# Altium schematic sheet Smart Agriculture Schematic (easyeda backup).SchDoc
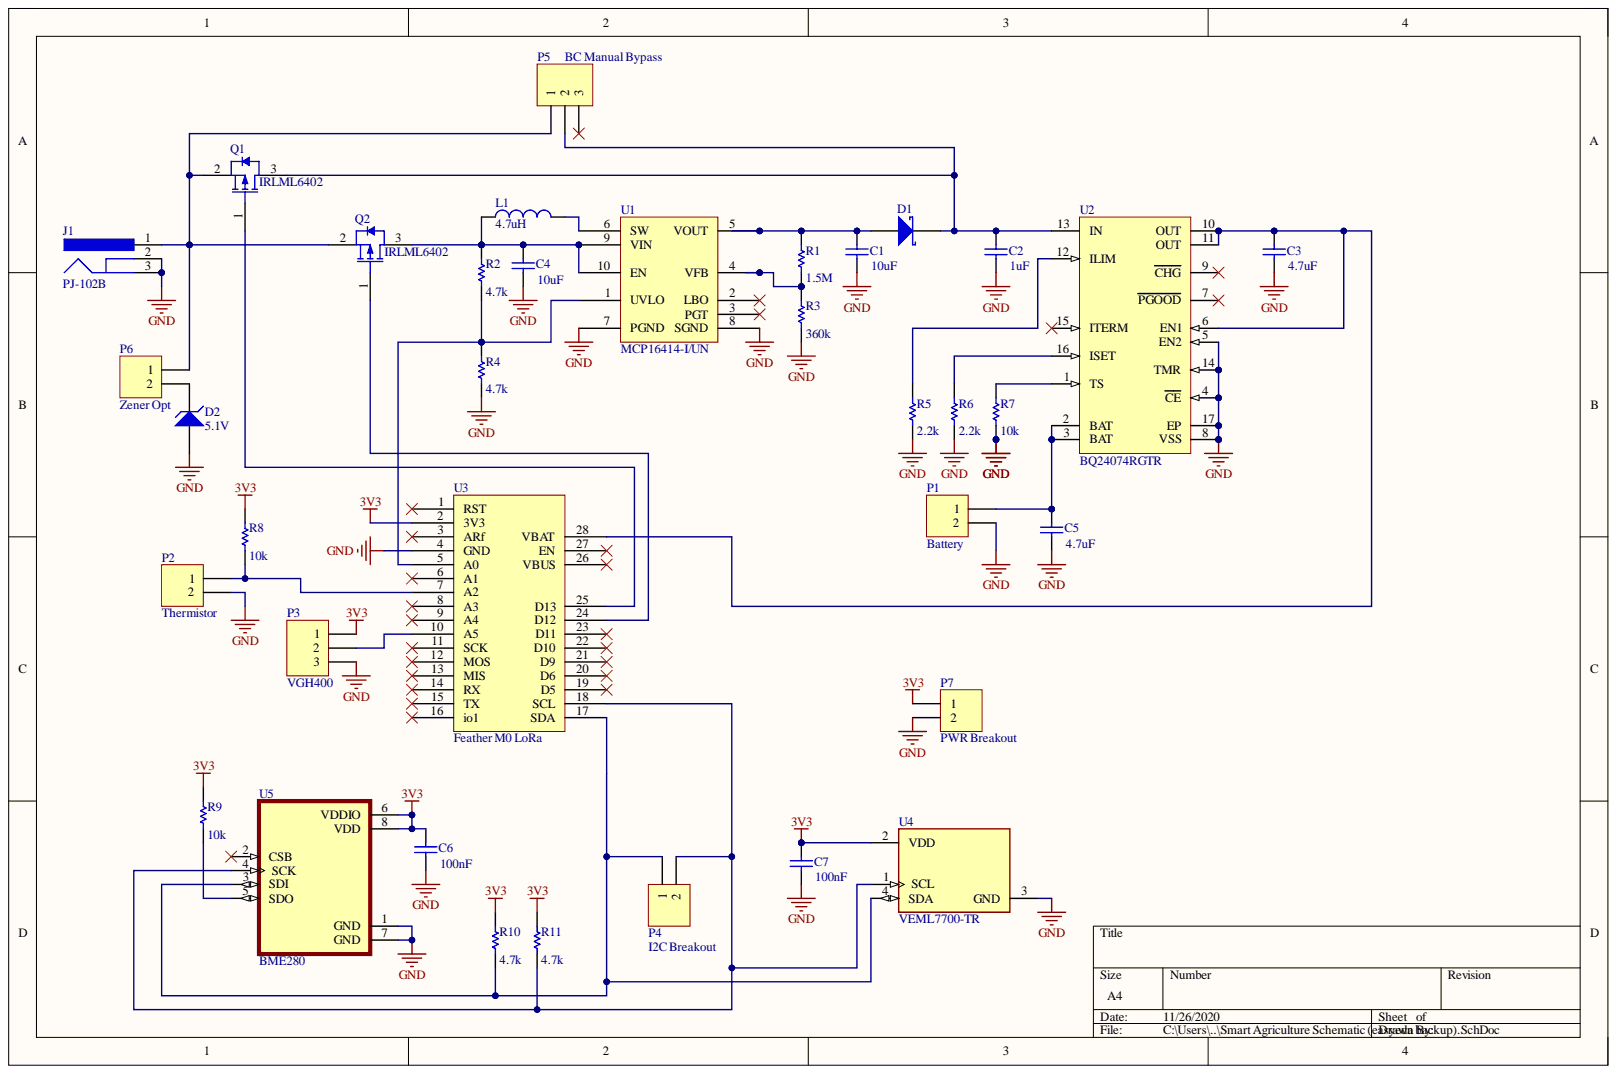
[diagram p0: Smart Agriculture Schematic (easyeda backup).SchDoc]

## Parts
C1  value 10uF  [1608[0603]]
C2  value 1uF  [1608[0603]]
C3  value 4.7uF  [1608[0603]]
C4  value 10uF  [1608[0603]]
C5  value 4.7uF  [1608[0603]]
C6  value 100nF  [1608[0603]]
C7  value 100nF  [1608[0603]]
D1  D Schottky  [SMB]  (value not drawn)
D2  5.1V  [SMC]
J1  PJ-102B  [CUI-PJ-102B_V]
L1  value 4.7uH  [1812]
P1  Battery  [HDR1X2]
P2  Thermistor  [HDR1X2]
P3  VGH400  [HDR1X3]
P4  I2C Breakout  [HDR1X2]
P5  BC Manual Bypass  [HDR1X3]
P6  Zener Opt  [HDR1X2]
P7  PWR Breakout  [HDR1X2]
Q1  IRLML6402  [INTR-SOT-23-3_V]
Q2  IRLML6402  [INTR-SOT-23-3_V]
R1  value 1.5M  [1608[0603]]
R2  value 4.7k  [1608[0603]]
R3  value 360k  [1608[0603]]
R4  value 4.7k  [1608[0603]]
R5  value 2.2k  [1608[0603]]
R6  value 2.2k  [1608[0603]]
R7  value 10k  [1608[0603]]
R8  value 10k  [1608[0603]]
R9  value 10k  [1608[0603]]
R10  value 4.7k  [1608[0603]]
R11  value 4.7k  [1608[0603]]
U1  MCP16414-I/UN  [MSOP10_UN_MCH]
U2  BQ24074RGTR  [RGT0016C_V]
U3  Feather M0 LoRa  [FeatherM0LoRa]
U4  VEML7700-TR  [FP-6_550-5300_02-4-MFG]
U5  BME280  [PSON65P250X250X100-8N]

## Nets
3V3: C6.2 C7.2 P3.1 P7.1 R8.2 R9.2 R10.2 R11.2 U3.2(3V3) U4.2(VDD) U5.6(VDDIO) U5.8(VDD)
GND: C1.1 C2.1 C3.1 C4.1 C5.1 C6.1 C7.1 D2.1 J1.2 J1.3 P1.2 P2.2 P3.3 P7.2 R3.1 R4.1 R5.1 R6.1 R7.1 U1.7(PGND) U1.8(SGND) U2.4(CE) U2.5(EN2) U2.8(VSS) U2.14(TMR) U2.17(EP) U3.4(GND) U4.3(GND) U5.1(GND) U5.7(GND)
NetC1_2: C1.2 D1.1 R1.2 U1.5(VOUT)
NetC2_2: C2.2 D1.2 P5.2 Q1.3 U2.13(IN)
NetC3_2: C3.2 U2.6(EN1) U2.10(OUT) U2.11(OUT) U3.28(VBAT)
NetC4_2: C4.2 L1.1 Q2.3 R2.2 U1.9(VIN) U1.10(EN)
NetC5_2: C5.2 P1.1 U2.2(BAT) U2.3(BAT)
NetD2_2: D2.2 P6.2
NetJ1_1: J1.1 P5.1 P6.1 Q1.2 Q2.2
NetL1_2: L1.2 U1.6(SW)
NetP2_1: P2.1 R8.1 U3.7(A2)
NetP3_2: P3.2 U3.10(A5)
NetP4_1: P4.1 R10.1 U3.17(SDA) U4.4(SDA) U5.3(SDI)
NetP4_2: P4.2 R11.1 U3.18(SCL) U4.1(SCL) U5.4(SCK)
NetQ1_1: Q1.1 U3.25(D13)
NetQ2_1: Q2.1 U3.24(D12)
NetR1_1: R1.1 R3.2 U1.4(VFB)
NetR2_1: R2.1 R4.2 U1.1(UVLO) U3.5(A0)
NetR5_2: R5.2 U2.12(ILIM)
NetR6_2: R6.2 U2.16(ISET)
NetR7_2: R7.2 U2.1(TS)
NetR9_1: R9.1 U5.5(SDO)

Not connected: P5 3; U1 2(LBO) 3(PGT); U2 7(PGOOD) 9(CHG) 15(ITERM); U3 1(RST) 3(ARf) 6(A1) 8(A3) 9(A4) 11(SCK) 12(MOS) 13(MIS) 14(RX) 15(TX) 16(io1) 19(D5) 20(D6) 21(D9) 22(D10) 23(D11) 26(VBUS) 27(EN); U5 2(CSB)

## Library data of the parts
C1-C7 = Cap: Capacitor; Component Kind: Standard; LatestRevisionDate: 17-Jul-2002; LatestRevisionNote: Re-released for DXP Platform.; Library Name: Miscellaneous Devices.IntLib; PackageDescription: Radial Cap, Thru-Hole; 2 Leads; 0.3 in Pin Spacing; PackageReference: RAD-0.3; Published: 8-Jun-2000; Signal Integrity: Cap; Simulation: CAP; Publisher: Altium Limited
D1 = D Schottky: Schottky Diode; Code_JEDEC: DO-214-AA; Component Kind: Standard; LatestRevisionDate: 17-Jul-2002; LatestRevisionNote: Re-released for DXP Platform.; Library Name: Miscellaneous Devices.IntLib; PackageDescription: DO-214-AA/SMB; 2 C-Bend Leads; Body 5.3 x 3.6 mm, inc. leads (LxW); PackageReference: SMB; Published: 8-Jun-2000; Signal Integrity: D Schottky; Simulation: SKYDIODE; Publisher: Altium Limited
D2 = D Zener: Zener Diode; Component Kind: Standard; LatestRevisionDate: 17-Jul-2002; LatestRevisionNote: Re-released for DXP Platform.; Library Name: Miscellaneous Devices.IntLib; PackageDescription: Diode, Thru-Hole; 2 Leads; 0.7 in Pin Spacing; PackageReference: DIODE-0.7; Published: 8-Jun-2000; Signal Integrity: D Zener; Simulation: ZENER; Publisher: Altium Limited
J1 = CMP-2000-06900-1: Through Hole Right Angle DC Power Jack, 2.5 A, 2.5 mm Center Pin, 3 Position, -25 to 85 degC, RoHS, Bulk; Manufacturer: CUIInc.; Brand: CUI; Current Rating: 2.5A; Inside Contact Diameter: 2.5mm; Insulation Resistance: 100MOhms; Outside Contact Diameter: 6.5mm; Voltage Rating: 24V; Component Kind: Standard; Connector Type: DCPowerJack; Contact Gender: Male; Contact Material: Copper; Contact Plating: Nickel; Datasheet URL: http://www.cui.com/product/resource/pj-102b.pdf; Datasheet Version: Rev. 1.03, 04/2016; Factory Pack Quantity: 280; Flammability Rating: UL94V-0; Housing Material: PolybutyleneTerephthalate(PBT); Library Name: Altium Content Vault; Manufacturer URL: http://www.cui.com/; Mounting Angle: Right; Mounting Style: ThroughHole; Mounting Technology: ThroughHole; Operating Temperature Range: -25Cto+85C; Package Description: 3-Pin Through Hole Device, Body 14.4 x 9 mm, Height 11 mm; Package Reference: PJ-102B; Packaging: Bulk; Product: Jacks; Product Category: DCPowerConnectors; RoHS: True; Termination Style: ThroughHole
L1 = Inductor: Code_IEC: 1005; Code_JEITA: 0402; Component Kind: Standard; Description: Inductor; LatestRevisionDate: 29-May-2009; LatestRevisionNote: IPC-7351 Footprint Added.; Library Name: Miscellaneous Devices.IntLib; PackageDescription: Chip Inductor; PackageReference: 0402-A; Published: 8-Jun-2000; Signal Integrity: Inductor; Simulation: INDUCTOR; Publisher: Altium Limited
P1 P2 P4 P6 P7 = Header 2: Header, 2-Pin; Component Kind: Standard; LatestRevisionDate: 17-Jul-2002; LatestRevisionNote: Re-released for DXP Platform.; Library Name: Miscellaneous Connectors.IntLib; Signal Integrity: Connector; Publisher: Altium Limited
P3 P5 = Header 3: Header, 3-Pin; Component Kind: Standard; LatestRevisionDate: 17-Jul-2002; LatestRevisionNote: Re-released for DXP Platform.; Library Name: Miscellaneous Connectors.IntLib; Signal Integrity: Connector; Publisher: Altium Limited
Q1 Q2 = CMP-2000-04987-1: HEXFET(R) Power P-Channel MOSFET, -20 V, -3.7 A, -55 to 150 degC, 3-Pin SOT-23, RoHS, Tape and Reel; Manufacturer: International Rectifier; Drain-Source On Resistance-Max: 0.065O; Drain-to-Source Voltage [Vdss]: 20V; Qg Gate Charge: 8nC; Rated Power Dissipation: 1.3W; Channel Type: P-Channel; Component Kind: Standard; Datasheet URL: http://datasheet.octopart.com/IRLML6402TRPBF-International-Rectifier-datasheet-93882.pdf; Datasheet Version: Feb-05; Library Name: Altium Content Vault; Manufacturer URL: http://internationalrectifier.com/; Package Description: 3-Pin Small Outline Transistor, Body 2.92 x 1.3 mm, Pitch 0.95 mm; Package Reference: SOT-23-3
R1-R11 = Res1: Resistor; Component Kind: Standard; LatestRevisionDate: 17-Jul-2002; LatestRevisionNote: Re-released for DXP Platform.; Library Name: Miscellaneous Devices.IntLib; PackageDescription: Axial Device, Thru-Hole; 2 Leads; 0.3 in Pin Spacing; PackageReference: AXIAL-0.3; Published: 8-Jun-2000; Signal Integrity: Res; Simulation: RESISTOR; Publisher: Altium Limited
U1 = Component_1: Component Kind: Standard; Library Name: MCP16414-IUN.SchLib
U2 = CMP-0321-00220-2: USB-Friendly Li-Ion Battery Charger and Power-Path Management IC, 10.5 V OVP, 4.3 V, -40 to 85 degC, 16-pin QFN (RGT), Green (RoHS & no Sb/Br); Manufacturer: Texas Instruments; Lead Acid: N; SubFamily: Battery Charge Management; Vin(Min)(V): 4.3; Code_JEDEC: MO-220; Component Kind: Standard; ComponentLink1Description: Datasheet; ComponentLink1URL: http://www.ti.com/general/docs/lit/getliterature.tsp?genericPartNumber=BQ24074&fileType=pdf; DatasheetVersion: SLUS810G; Eco-Plan: Green (RoHS & no Sb/Br); Li-Ion: N; Li-Polymer: N; Library Name: Altium Content Vault; Mounting Technology: Surface Mount; NiCd: N; NiMH: N; Over Voltage Protection: N; PackageDescription: 16-Pin Plastic Quad Flat No-Lead, 3 x 3 mm Body, 0.5 mm Pitch, 1.7 x 1.7 mm Exposed Pad; PackageReference: RGT0016C; PackageVersion: revH, Oct-2011; PartNumber: BQ24074RGTR
U4 = CMP-02530-000005-1: SENSOR OPT 545NM AMBIENT 4SMD; Manufacturer: Vishay; Max Junction Temp: +100°C; Max Supply Voltage: 3.6V; Min Supply Voltage: 2.5V; Operating Supply Current: 2-45uA; Sensor Output: I2C; Ambient Temperature Range High: +85°C; Ambient Temperature Range Low: -25°C; Automotive: No; Category: IC; Component Kind: Standard; ComponentLink2Description: Datasheet; ComponentLink2URL: http://www.vishay.com/docs/84286/veml7700.pdf; Device Class L1: Sensors; Device Class L2: Optical Sensors; Device Class L3: unset; Digikey Description: SENSOR OPT 545NM AMBIENT 4SMD; Height: 3.15mm; Lead Free: Yes; Library Name: Altium Content Vault; Manufacturer Part Number: VEML7700-TR; Octopart: https://octopart.com/veml7700-tr-vishay-70519713; Package: 6.550-5300.02-4; RoHS: TRUE; Temperature: to +100°C; Temperature Range High: +100°C
U5 = BME280: Humidity Temperature Sensor 0 ~ 100% RH IÂ²C, SPI Â±3% 1s Surface Mount; MF: Bosch; Availability: Unavailable; Component Kind: Standard; Library Name: BME280.IntLib; MP: BME280; Package: LGA-8 Bosch; Price: None; SnapEDA_Link: https://www.snapeda.com/parts/BME280/Bosch%20Sensortec/view-part/49769/?ref=snap
U3 = Feather M0 LoRa: Component Kind: Standard; Library Name: FeatherM0LoRa.SchLib
